# Altium schematic sheet zigbee.SchDoc
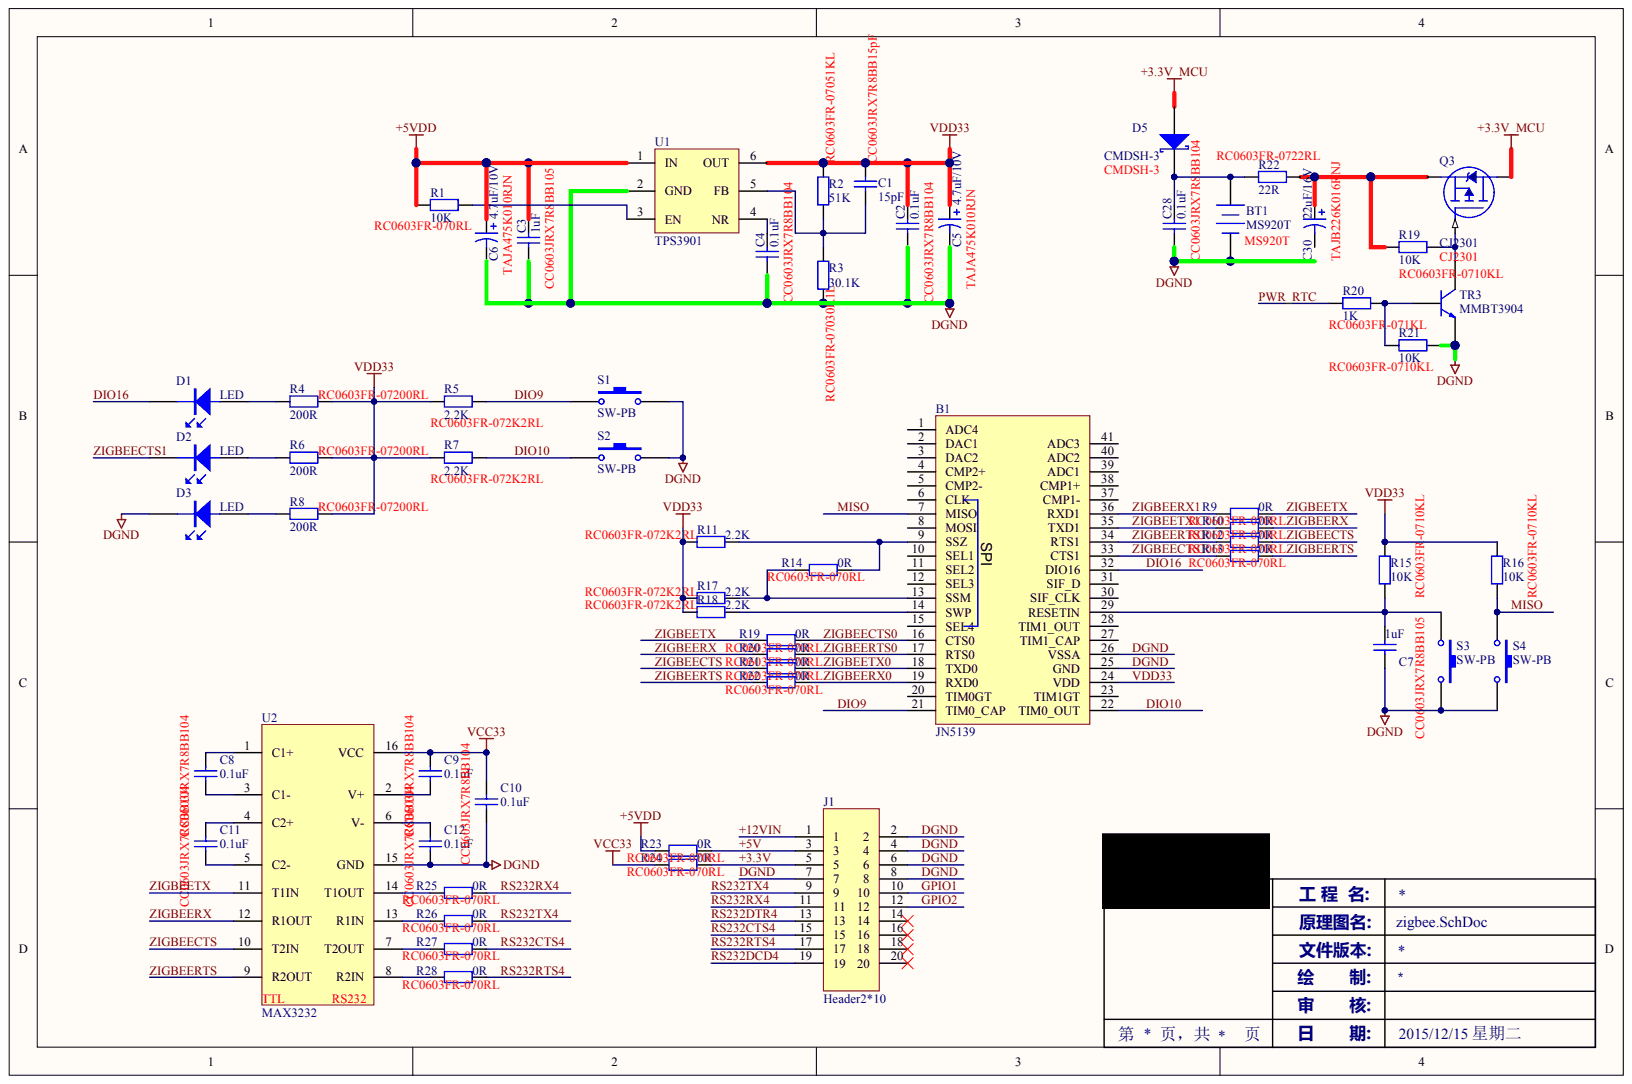
[diagram p0: zigbee.SchDoc]

## Parts
B1  JN5139
BT1  MS920T
C1  15pF  Type No.: CC0603JRX7R8BB15pF
C2  0.1uF  Type No.: CC0603JRX7R8BB104
C3  1uF  Type No.: CC0603JRX7R8BB105
C4  0.1uF  Type No.: CC0603JRX7R8BB104
C5  4.7uF/10V  Type No.: TAJA475K010RJN
C6  4.7uF/10V  Type No.: TAJA475K010RJN
C7  1uF  Type No.: CC0603JRX7R8BB105
C8  0.1uF  Type No.: CC0603JRX7R8BB104
C9  0.1uF  Type No.: CC0603JRX7R8BB104
C10  0.1uF  Type No.: CC0603JRX7R8BB104
C11  0.1uF  Type No.: CC0603JRX7R8BB104
C12  0.1uF  Type No.: CC0603JRX7R8BB104
C28  0.1uF  Type No.: CC0603JRX7R8BB104
C30  22uF/16V  Part No.: TAJB226K016RNJ
D1  LED
D2  LED
D3  LED
D5  CMDSH-3
J1  Header2*10
Q3  CJ2301
R1  10K
R2  51K  Type No.: RC0603FR-07051KL
R3  30.1K  Type No.: RC0603FR-07030K1L
R4  200R  Type No.: RC0603FR-07200RL
R5  2.2K  Type No.: RC0603FR-072K2RL
R6  200R  Type No.: RC0603FR-07200RL
R7  2.2K  Type No.: RC0603FR-072K2RL
R8  200R  Type No.: RC0603FR-07200RL
R9  0R  Type No.: RC0603FR-070RL
R10  0R  Type No.: RC0603FR-070RL
R11  2.2K
R12  0R  Type No.: RC0603FR-070RL
R13  0R  Type No.: RC0603FR-070RL
R14  0R  Type No.: RC0603FR-070RL
R15  10K
R16  10K  Type No.: RC0603FR-0710KL
R17  2.2K
R18  2.2K
R19  10K
R19  0R  Type No.: RC0603FR-070RL
R20  1K
R20  0R  Type No.: RC0603FR-070RL
R21  10K  Type No.: RC0603FR-0710KL
R21  0R  Type No.: RC0603FR-070RL
R22  22R  Type No.: RC0603FR-0722RL
R22  0R  Type No.: RC0603FR-070RL
R23  0R  Type No.: RC0603FR-070RL
R24  0R  Type No.: RC0603FR-070RL
R25  0R  Type No.: RC0603FR-070RL
R26  0R  Type No.: RC0603FR-070RL
R27  0R  Type No.: RC0603FR-070RL
R28  0R  Type No.: RC0603FR-070RL
S1  SW-PB
S2  SW-PB
S3  SW-PB
S4  SW-PB
TR3  MMBT3904
U1  TPS3901
U2  MAX3232

## Nets
+3.3V_MCU: D5.1 Q3.3
+5VDD: C3.2 C6.1 R1.2 R23.2 U1.1(IN)
VCC33: C9.2 C10.2 R24.2 U2.16(VCC)
+3.3V: J1.5 R24.1
+5V: J1.3 R23.1
+12VIN: J1.1
DGND: B1.25(GND) B1.26(VSSA) BT1.2 C2.1 C3.1 C4.1 C5.2 C6.2 C7.1 C10.1 C12.1 C28.1 D3.2 J1.2 J1.4 J1.6 J1.7 J1.8 R3.1 R21.1 S1.2 S2.2 S3.2 S4.2 TR3.2 U1.2(GND) U2.15(GND)
DIO9: B1.21(TIM0_CAP) R5.2 S1.1
DIO10: B1.22(TIM0_OUT) R7.2 S2.1
DIO16: B1.32(DIO16) D1.2
GPIO1: J1.10
GPIO2: J1.12
MISO: B1.7(MISO) R16.2 S4.1
PWR_RTC: R20.2
RS232CTS4: J1.15 R27.1
RS232DCD4: J1.19
RS232DTR4: J1.13
RS232RTS4: J1.17 R28.1
RS232RX4: J1.11 R25.1
RS232TX4: J1.9 R26.1
VDD33: B1.24(VDD) C1.1 C2.2 C5.1 R2.1 R4.2 R5.1 R6.2 R7.1 R8.2 R11.1 R15.1 R16.1 R17.1 R18.1 U1.6(OUT)
ZIGBEECTS: R12.1 R21.2 U2.10(T2IN)
ZIGBEECTS0: B1.16(CTS0) R19.1
ZIGBEECTS1: B1.33(CTS1) D2.2 R13.2
ZIGBEERTS: R13.1 R22.2 U2.9(R2OUT)
ZIGBEERTS0: B1.17(RTS0) R20.1
ZIGBEERTS1: B1.34(RTS1) R12.2
ZIGBEERX: R10.1 R20.2 U2.12(R1OUT)
ZIGBEERX0: B1.19(RXD0) R22.1
ZIGBEERX1: B1.36(RXD1) R9.2
ZIGBEETX: R9.1 R19.2 U2.11(T1IN)
ZIGBEETX0: B1.18(TXD0) R21.1
ZIGBEETX1: B1.35(TXD1) R10.2
NetB1_9: B1.9(SSZ) R11.2 R14.1
NetB1_13: B1.13(SSM) R14.2 R17.2
NetB1_14: B1.14(SWP) R18.2
NetB1_29: B1.29(RESETIN) C7.2 R15.2 S3.1
NetBT1_1: BT1.1 C28.2 D5.2 R22.2
NetC1_2: C1.2 R2.2 R3.2 U1.5(FB)
NetC4_2: C4.2 U1.4(NR)
NetC8_1: C8.1 U2.3(C1-)
NetC8_2: C8.2 U2.1(C1+)
NetC9_1: C9.1 U2.2(V+)
NetC11_1: C11.1 U2.5(C2-)
NetC11_2: C11.2 U2.4(C2+)
NetC12_2: C12.2 U2.6(V-)
NetC30_1: C30.1 Q3.2 R19.2 R22.1
NetD1_1: D1.1 R4.1
NetD2_1: D2.1 R6.1
NetD3_1: D3.1 R8.1
NetQ3_1: Q3.1 R19.1 TR3.3
NetR1_1: R1.1 U1.3(EN)
NetR20_1: R20.1 R21.2 TR3.1
NetR25_2: R25.2 U2.14(T1OUT)
NetR26_2: R26.2 U2.13(R1IN)
NetR27_2: R27.2 U2.7(T2OUT)
NetR28_2: R28.2 U2.8(R2IN)

Not connected: B1 1(ADC4) 2(DAC1) 3(DAC2) 4(CMP2+) 5(CMP2-) 6(CLK) 8(MOSI) 10(SEL1) 11(SEL2) 12(SEL3) 15(SEL4) 20(TIM0GT) 23(TIM1GT) 27(TIM1_CAP) 28(TIM1_OUT) 30(SIF_CLK) 31(SIF_D) 37(CMP1-) 38(CMP1+) 39(ADC1) 40(ADC2) 41(ADC3); C30 2; J1 14 16 18 20

## Library data of the parts
R1 = (no symbol name): Type No.: RC0603FR-070RL
R11 R17 R18 = (no symbol name): Type No.: RC0603FR-072K2RL
R15 R19 = (no symbol name): Type No.: RC0603FR-0710KL
R20 = (no symbol name): Type No.: RC0603FR-071KL
B1 BT1 C1-C12 C28 C30 D1-D3 D5 J1 Q3 R2-R10 R12-R14 R16 R19-R21 R21 R22 R22-R28 S1-S4 TR3 U1 U2 = (no symbol name)
  BT1: Type No.: MS920T
  D5: Type No.: CMDSH-3
  Q3: Type No.: CJ2301
  S1-S4: Published: 24-Mar-1999; LatestRevisionDate: 17-Jul-2002; LatestRevisionNote: Re-released for DXP Platform.; PackageReference: SPST-2; Publisher: Altium Limited
